# Altium schematic sheet MCU.SchDoc
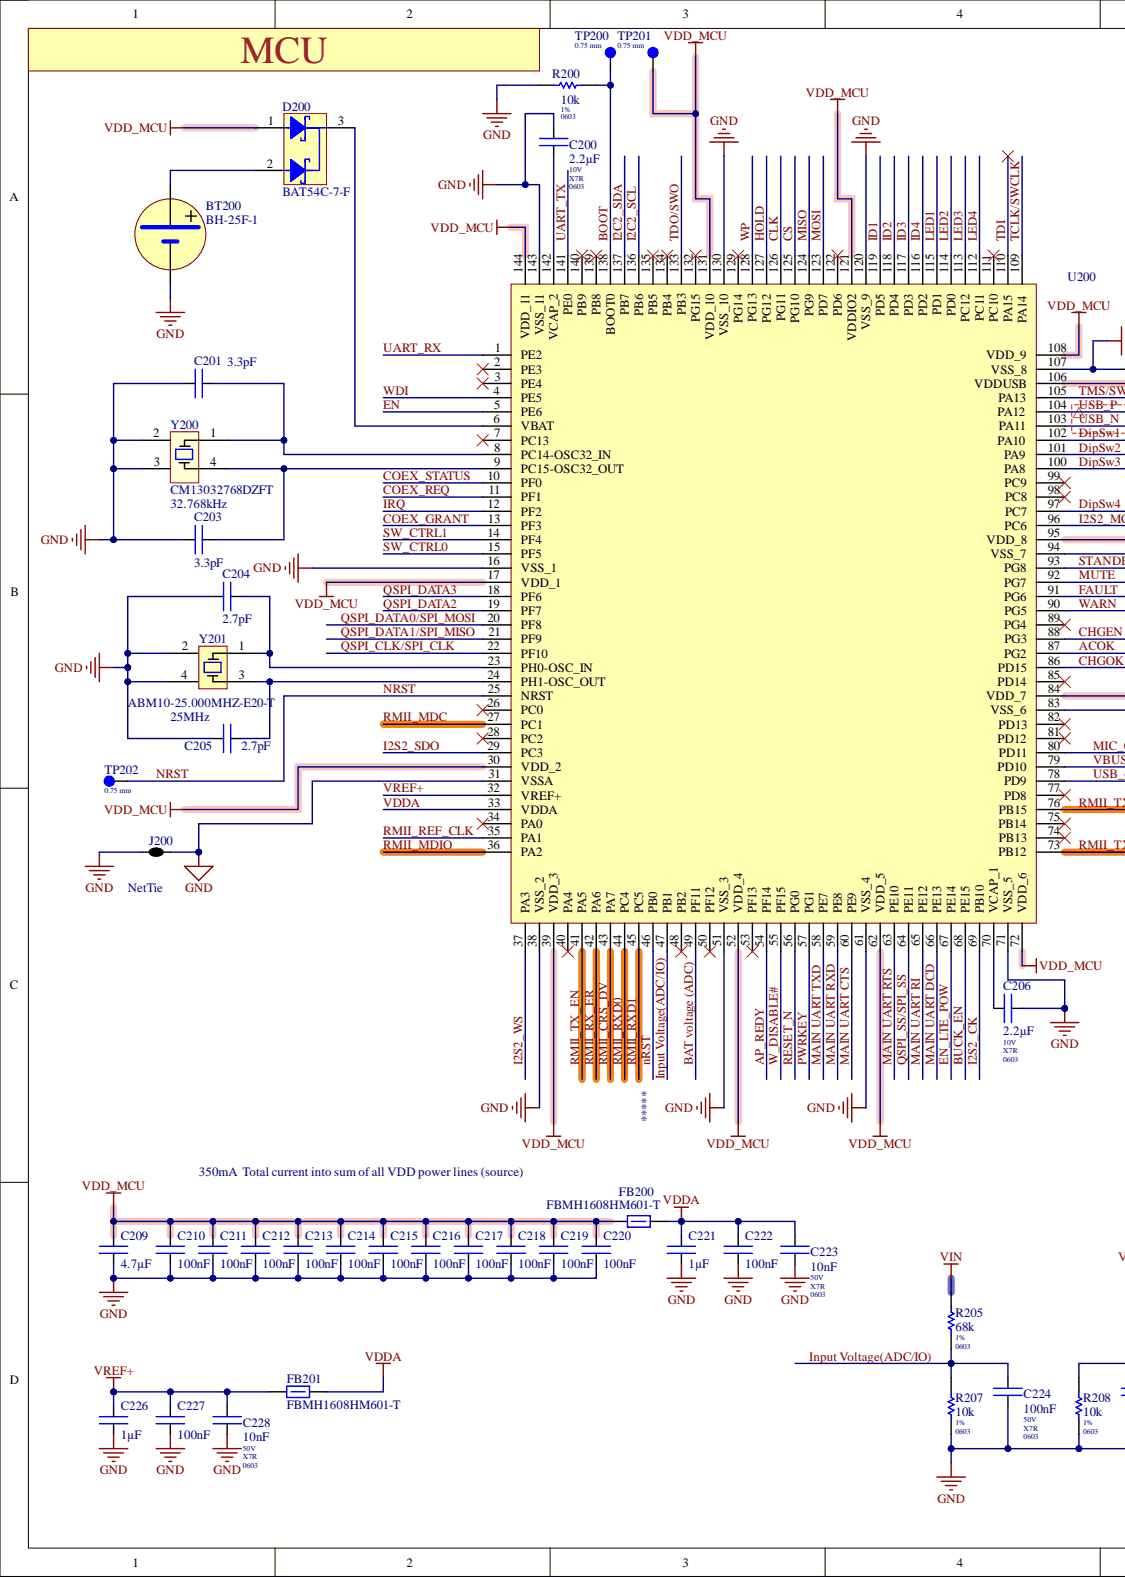
[diagram p0: MCU.SchDoc, left]
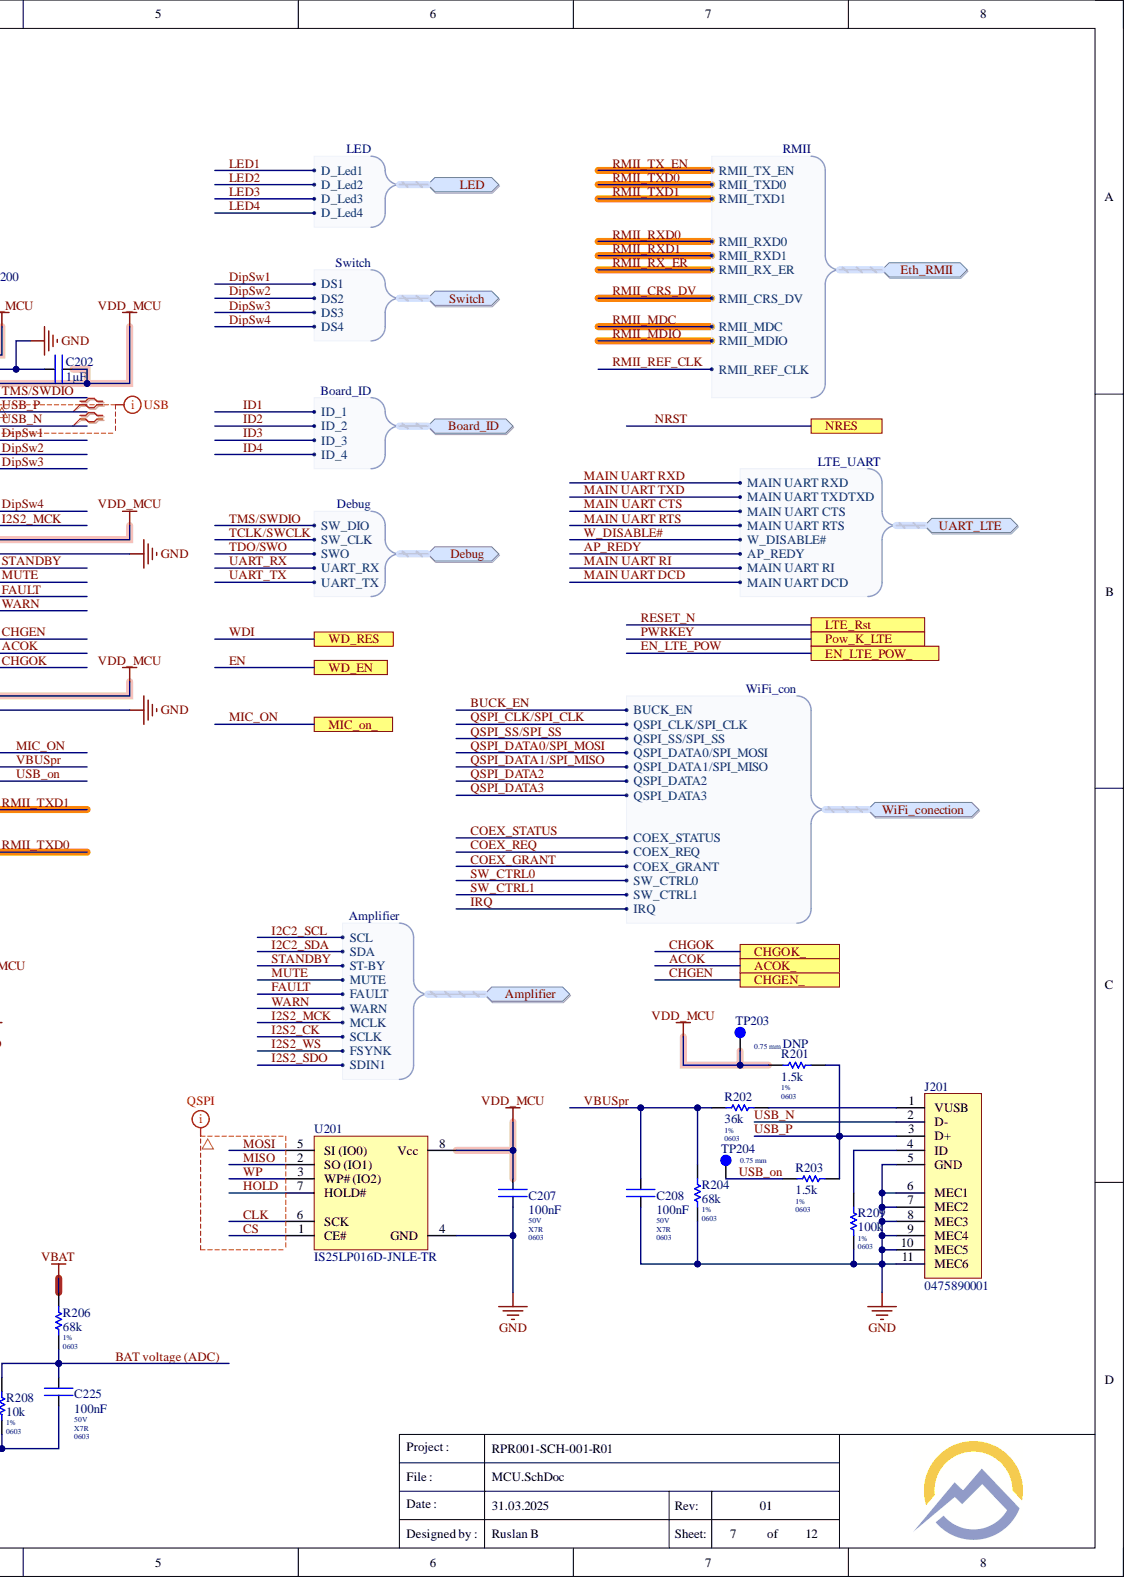
[diagram p1: MCU.SchDoc, right]

## Parts
BT200  BH-25F-1  [BH-25F-1]
C200  value 2.2µF  Case/Package: 0603  Dielectric: X7R  Voltage Rating: 10V  [B_Cap_0603_KEM]  (hidden comment: CL10B225KP8NNNC)
C201  value 3.3pF  [B_Cap_0402_KEM]  (hidden comment: GRM1555C1H3R3WA01D)
C202  value 1µF  [B_Cap_0402_KEM]  (hidden comment: EMK105BJ105KV-F)
C203  value 3.3pF  [B_Cap_0402_KEM]  (hidden comment: GRM1555C1H3R3WA01D)
C204  value 2.7pF  [B_Cap_0402_KEM]  (hidden comment: CC0402BRNPO9BN2R7)
C205  value 2.7pF  [B_Cap_0402_KEM]  (hidden comment: CC0402BRNPO9BN2R7)
C206  value 2.2µF  Dielectric: X7R  Voltage Rating: 10V  [B_Cap_0603_KEM]  (hidden comment: CL10B225KP8NNNC)
C207  value 100nF  Case/Package: 0603  Dielectric: X7R  Voltage Rating: 50V  [B_Cap_0603_KEM]  (hidden comment: GCM188R71H104KA57D)
C208  value 100nF  Case/Package: 0603  Dielectric: X7R  Voltage Rating: 50V  [B_Cap_0603_KEM]  (hidden comment: GCM188R71H104KA57D)
C209  value 4.7µF  [B_Cap_0402_KEM]  (hidden comment: CL05A475MO5NUNC)
C210  value 100nF  [B_Cap_0402_KEM]  (hidden comment: GRM155R71H104ME14D)
C211  value 100nF  [B_Cap_0402_KEM]  (hidden comment: GRM155R71H104ME14D)
C212  value 100nF  [B_Cap_0402_KEM]  (hidden comment: GRM155R71H104ME14D)
C213  value 100nF  [B_Cap_0402_KEM]  (hidden comment: GRM155R71H104ME14D)
C214  value 100nF  [B_Cap_0402_KEM]  (hidden comment: GRM155R71H104ME14D)
C215  value 100nF  [B_Cap_0402_KEM]  (hidden comment: GRM155R71H104ME14D)
C216  value 100nF  [B_Cap_0402_KEM]  (hidden comment: GRM155R71H104ME14D)
C217  value 100nF  [B_Cap_0402_KEM]  (hidden comment: GRM155R71H104ME14D)
C218  value 100nF  [B_Cap_0402_KEM]  (hidden comment: GRM155R71H104ME14D)
C219  value 100nF  [B_Cap_0402_KEM]  (hidden comment: GRM155R71H104ME14D)
C220  value 100nF  [B_Cap_0402_KEM]  (hidden comment: GRM155R71H104ME14D)
C221  value 1µF  [B_Cap_0402_KEM]  (hidden comment: EMK105BJ105KV-F)
C222  value 100nF  [B_Cap_0402_KEM]  (hidden comment: GRM155R71H104ME14D)
C223  value 10nF  Case/Package: 0603  Dielectric: X7R  Voltage Rating: 50V  [B_Cap_0603_KEM]  (hidden comment: CC0603MRX7R9BB103)
C224  value 100nF  Case/Package: 0603  Dielectric: X7R  Voltage Rating: 50V  [B_Cap_0603_KEM]  (hidden comment: GCM188R71H104KA57D)
C225  value 100nF  Case/Package: 0603  Dielectric: X7R  Voltage Rating: 50V  [B_Cap_0603_KEM]  (hidden comment: GCM188R71H104KA57D)
C226  value 1µF  [B_Cap_0402_KEM]  (hidden comment: EMK105BJ105KV-F)
C227  value 100nF  [B_Cap_0402_KEM]  (hidden comment: GRM155R71H104ME14D)
C228  value 10nF  Case/Package: 0603  Dielectric: X7R  Voltage Rating: 50V  [B_Cap_0603_KEM]  (hidden comment: CC0603MRX7R9BB103)
D200  BAT54C-7-F  [TO-236-3, SC-59, SOT-23-3]
FB200  FBMH1608HM601-T  [FBMH1608HM601-T-Footprint-1]
FB201  FBMH1608HM601-T  [FBMH1608HM601-T-Footprint-1]
J200  NetTie  [NetTie_2way_LP]
J201  0475890001  [PCBComponent]
R200  value 10k  [Res_0603_YAG]  (hidden comment: RC0603FR-0710KL)
R201  value 1.5k  Assembly: DNP  Tolerance: 1%  [Res_0603_YAG]  (hidden comment: RC0603FR-071K5L)
R202  value 36k  Case/Package: 0603  Tolerance: 1%  [Res_0603_YAG]  (hidden comment: RC0603FR-0736KL)
R203  value 1.5k  Case/Package: 0603  Tolerance: 1%  [Res_0603_YAG]  (hidden comment: RC0603FR-071K5L)
R204  value 68k  Case/Package: 0603  Tolerance: 1%  [Res_0603_YAG]  (hidden comment: RC0603FR-0768KL)
R205  value 68k  Case/Package: 0603  Tolerance: 1%  [Res_0603_YAG]  (hidden comment: RC0603FR-0768KL)
R206  value 68k  Case/Package: 0603  Tolerance: 1%  [Res_0603_YAG]  (hidden comment: RC0603FR-0768KL)
R207  value 10k  Case/Package: 0603  Tolerance: 1%  [Res_0603_YAG]  (hidden comment: RC0603FR-0710KL)
R208  value 10k  Case/Package: 0603  Tolerance: 1%  [Res_0603_YAG]  (hidden comment: RC0603FR-0710KL)
R209  value 100k  Case/Package: 0603  Tolerance: 1%  [Res_0603_YAG]  (hidden comment: RMCF0603FT100K)
TP200  0.75 mm  [0.75mm]  (no BOM)
TP201  0.75 mm  [0.75mm]  (no BOM)
TP202  0.75 mm  [0.75mm]  (no BOM)
TP203  0.75 mm  [0.75mm]  (no BOM)
TP204  0.75 mm  [0.75mm]  (no BOM)
U200  (no value)  [STM32H573ZIT6]
U201  IS25LP016D-JNLE-TR  [8-SOIC]
Y200  CM13032768DZFT  [CM130]
Y201  ABM10-25.000MHZ-E20-T  [4-SMD, No Lead]

## Ports
ACOK_, Amplifier, Amplifier.FAULT, Amplifier.FSYNK, Amplifier.MCLK, Amplifier.MUTE, Amplifier.SCL, Amplifier.SCLK, Amplifier.SDA, Amplifier.SDIN1, Amplifier.ST-BY, Amplifier.WARN, Board_ID, Board_ID.ID_1, Board_ID.ID_2, Board_ID.ID_3, Board_ID.ID_4, CHGEN_, CHGOK_, Debug, Debug.SW_CLK, Debug.SW_DIO, Debug.SWO, Debug.UART_RX, Debug.UART_TX, EN_LTE_POW_, Eth_RMII, Eth_RMII.RMII_CRS_DV, Eth_RMII.RMII_MDC, Eth_RMII.RMII_MDIO, Eth_RMII.RMII_REF_CLK, Eth_RMII.RMII_RX_ER, Eth_RMII.RMII_RXD0, Eth_RMII.RMII_RXD1, Eth_RMII.RMII_TX_EN, Eth_RMII.RMII_TXD0, Eth_RMII.RMII_TXD1, LED, LED.D_Led1, LED.D_Led2, LED.D_Led3, LED.D_Led4, LTE_Rst, MIC_on_, NRES, Pow_K_LTE, Switch, Switch.DS1, Switch.DS2, Switch.DS3, Switch.DS4, UART_LTE, UART_LTE.AP_REDY, UART_LTE.MAIN UART CTS, UART_LTE.MAIN UART DCD, UART_LTE.MAIN UART RI, UART_LTE.MAIN UART RTS, UART_LTE.MAIN UART RXD, UART_LTE.MAIN UART TXDTXD, UART_LTE.W_DISABLE#, WD_EN, WD_RES, WiFi_conection, WiFi_conection.BUCK_EN, WiFi_conection.COEX_GRANT, WiFi_conection.COEX_REQ, WiFi_conection.COEX_STATUS, WiFi_conection.IRQ, WiFi_conection.QSPI_CLK/SPI_CLK, WiFi_conection.QSPI_DATA0/SPI_MOSI, WiFi_conection.QSPI_DATA1/SPI_MISO, WiFi_conection.QSPI_DATA2, WiFi_conection.QSPI_DATA3, WiFi_conection.QSPI_SS/SPI_SS, WiFi_conection.SW_CTRL0, WiFi_conection.SW_CTRL1

## Nets
GND: BT200.1 C200.1 C201.1 C202.2 C203.2 C204.2 C205.2 C206.1 C207.1 C208.1 C209.1 C210.1 C211.1 C212.1 C213.1 C214.1 C215.1 C216.1 C217.1 C218.1 C219.1 C220.1 C221.1 C222.1 C223.1 C224.1 C225.1 C226.1 C227.1 C228.1 J200.1 J200.2 J201.5(GND) J201.6(MEC1) J201.7(MEC2) J201.8(MEC3) J201.9(MEC4) J201.10(MEC5) J201.11(MEC6) R200.1 R204.1 R207.1 R208.1 R209.2 U200.16(VSS_1) U200.31(VSSA) U200.38(VSS_2) U200.51(VSS_3) U200.61(VSS_4) U200.71(VSS_5) U200.83(VSS_6) U200.94(VSS_7) U200.107(VSS_8) U200.120(VSS_9) U200.130(VSS_10) U200.143(VSS_11) U201.4(GND) Y200.2 Y200.3 Y201.2 Y201.4
VBAT: R206.2
VDD_MCU: C202.1 C207.2 C209.2 C210.2 C211.2 C212.2 C213.2 C214.2 C215.2 C216.2 C217.2 C218.2 C219.2 C220.2 D200.1 FB200.2 R201.1 TP201.1 TP203.1 U200.17(VDD_1) U200.30(VDD_2) U200.39(VDD_3) U200.52(VDD_4) U200.62(VDD_5) U200.72(VDD_6) U200.84(VDD_7) U200.95(VDD_8) U200.106(VDDUSB) U200.108(VDD_9) U200.121(VDDIO2) U200.131(VDD_10) U200.144(VDD_11) U201.8(Vcc)
VIN: R205.2
ACOK: U200.87(PG2) ; port ACOK_
AP_REDY: U200.54(PF14) ; port UART_LTE
BAT voltage (ADC): C225.2 R206.1 R208.2 U200.49(PF11)
BOOT: R200.2 TP200.1 U200.138(BOOT0)
BUCK_EN: U200.68(PE15) ; port WiFi_conection
CHGEN: U200.88(PG3) ; port CHGEN_
CHGOK: U200.86(PD15) ; port CHGOK_
CLK: U200.126(PG11) U201.6(SCK)
COEX_GRANT: U200.13(PF3) ; port WiFi_conection
COEX_REQ: U200.11(PF1) ; port WiFi_conection
COEX_STATUS: U200.10(PF0) ; port WiFi_conection
CS: U200.125(PG10) U201.1(CE#)
DipSw1: U200.102(PA10) ; port Switch
DipSw2: U200.101(PA9) ; port Switch
DipSw3: U200.100(PA8) ; port Switch
DipSw4: U200.97(PC7) ; port Switch
EN: U200.5(PE6) ; port WD_EN
EN_LTE_POW: U200.67(PE14) ; port EN_LTE_POW_
FAULT: U200.91(PG6) ; port Amplifier
HOLD: U200.127(PG12) U201.7(HOLD#)
I2C2_SCL: U200.136(PB6) ; port Amplifier
I2C2_SDA: U200.137(PB7) ; port Amplifier
I2S2_CK: U200.69(PB10) ; port Amplifier
I2S2_MCK: U200.96(PC6) ; port Amplifier
I2S2_SDO: U200.29(PC3) ; port Amplifier
I2S2_WS: U200.37(PA3) ; port Amplifier
ID1: U200.119(PD5) ; port Board_ID
ID2: U200.118(PD4) ; port Board_ID
ID3: U200.117(PD3) ; port Board_ID
ID4: U200.116(PD2) ; port Board_ID
Input Voltage(ADC/IO): C224.2 R205.1 R207.2 U200.47(PB1)
IRQ: U200.12(PF2) ; port WiFi_conection
LED1: U200.115(PD1) ; port LED
LED2: U200.114(PD0) ; port LED
LED3: U200.113(PC12) ; port LED
LED4: U200.112(PC11) ; port LED
MAIN UART CTS: U200.60(PE9) ; port UART_LTE
MAIN UART DCD: U200.66(PE13) ; port UART_LTE
MAIN UART RI: U200.65(PE12) ; port UART_LTE
MAIN UART RTS: U200.63(PE10) ; port UART_LTE
MAIN UART RXD: U200.59(PE8) ; port UART_LTE
MAIN UART TXD: U200.58(PE7) ; port UART_LTE
MIC_ON: U200.80(PD11) ; port MIC_on_
MISO: U200.124(PG9) U201.2(SO_(IO1))
MOSI: U200.123(PD7) U201.5(SI_(IO0))
MUTE: U200.92(PG7) ; port Amplifier
nRST: TP202.1 U200.25(NRST) U200.46(PB0) ; port NRES
PWRKEY: U200.57(PG1) ; port Pow_K_LTE
QSPI_CLK/SPI_CLK: U200.22(PF10) ; port WiFi_conection
QSPI_DATA0/SPI_MOSI: U200.20(PF8) ; port WiFi_conection
QSPI_DATA1/SPI_MISO: U200.21(PF9) ; port WiFi_conection
QSPI_DATA2: U200.19(PF7) ; port WiFi_conection
QSPI_DATA3: U200.18(PF6) ; port WiFi_conection
QSPI_SS/SPI_SS: U200.64(PE11) ; port WiFi_conection
RESET_N: U200.56(PG0) ; port LTE_Rst
RMII_CRS_DV: U200.43(PA7) ; port Eth_RMII
RMII_MDC: U200.27(PC1) ; port Eth_RMII
RMII_MDIO: U200.36(PA2) ; port Eth_RMII
RMII_REF_CLK: U200.35(PA1) ; port Eth_RMII
RMII_RX_ER: U200.42(PA6) ; port Eth_RMII
RMII_RXD0: U200.44(PC4) ; port Eth_RMII
RMII_RXD1: U200.45(PC5) ; port Eth_RMII
RMII_TX_EN: U200.41(PA5) ; port Eth_RMII
RMII_TXD0: U200.73(PB12) ; port Eth_RMII
RMII_TXD1: U200.76(PB15) ; port Eth_RMII
STANDBY: U200.93(PG8) ; port Amplifier
SW_CTRL0: U200.15(PF5) ; port WiFi_conection
SW_CTRL1: U200.14(PF4) ; port WiFi_conection
TCLK/SWCLK: U200.109(PA14) ; port Debug
TDI: U200.110(PA15)
TDO/SWO: U200.133(PB3) ; port Debug
TMS/SWDIO: U200.105(PA13) ; port Debug
UART_RX: U200.1(PE2) ; port Debug
UART_TX: U200.141(PE0) ; port Debug
USB_N: J201.2(D-) U200.103(PA11)
USB_on: R203.1 TP204.1 U200.78(PD9)
USB_P: J201.3(D+) R201.2 R203.2 U200.104(PA12)
VBUSpr: C208.2 R202.1 R204.2 U200.79(PD10)
VDDA: C221.2 C222.2 C223.2 FB200.1 FB201.1 U200.33(VDDA)
VREF+: C226.2 C227.2 C228.2 FB201.2 U200.32(VREF+)
W_DISABLE#: U200.55(PF15) ; port UART_LTE
WARN: U200.90(PG5) ; port Amplifier
WDI: U200.4(PE5) ; port WD_RES
WP: U200.128(PG13) U201.3(WP#_(IO2))
NetBT200_2: BT200.2 D200.2
NetC200_2: C200.2 U200.142(VCAP_2)
NetC201_2: C201.2 U200.8(PC14-OSC32_IN) Y200.1
NetC203_1: C203.1 U200.9(PC15-OSC32_OUT) Y200.4
NetC204_1: C204.1 U200.23(PH0-OSC_IN) Y201.1
NetC205_1: C205.1 U200.24(PH1-OSC_OUT) Y201.3
NetC206_2: C206.2 U200.70(VCAP_1)
NetD200_3: D200.3 U200.6(VBAT)
NetJ201_1: J201.1(VUSB) R202.2
NetJ201_4: J201.4(ID) R209.1

Not connected: U200 2(PE3) 3(PE4) 7(PC13) 26(PC0) 28(PC2) 34(PA0) 40(PA4) 48(PB2) 50(PF12) 53(PF13) 74(PB13) 75(PB14) 77(PD8) 81(PD12) 82(PD13) 85(PD14) 89(PG4) 98(PC8) 99(PC9) 111(PC10) 122(PD6) 129(PG14) 132(PG15) 134(PB4) 135(PB5) 139(PB8) 140(PB9)

## Library data of the parts
BT200 = CMP-POW-BAT-00020-3: BATTERY HOLDER CR 2032; Manufacturer 1: Adam Equipment; Number of Cells: 1; Component Kind: Standard; ComponentLink1Description: Datasheet; ComponentLink1URL: https://www.adam-tech.com/downloader.php?p=BH-25F-1.pdf; Library Name: <Company> Server; Manufacturer Part Number 1: BH-25F-1
C200 C206 = CMP-CAP-CER-00144-13: CAP CER 2.2UF 10V X7R 0603; Manufacturer 1: Samsung; Tolerance: ±10%; Component Kind: Standard; ComponentLink1Description: Datasheet; ComponentLink1URL: http://www.samsungsem.com/kr/support/product-search/mlcc/CL10B225KP8NNNC.jsp; Library Name: <Company> Server; Manufacturer Part Number 1: CL10B225KP8NNNC
  C206: Case/Package: 0603
C201 C203 = CMP-CAP-CER-00179-16: CAP CER 3.3PF 50V C0G/NP0 0402; Manufacturer 1: Murata; Tolerance: ±0.05pF; Component Kind: Standard; ComponentLink1Description: Datasheet; ComponentLink1URL: https://www.murata.com/~/media/webrenewal/support/library/catalog/products/capacitor/mlcc/c02e.ashx?la=en-us; Library Name: <Company> Server; Manufacturer Part Number 1: GRM1555C1H3R3WA01D
C202 C221 C226 = CMP-CAP-CER-00130-16: CAP CER 1UF 16V X5R 0402; Manufacturer 1: Taiyo Yuden; Tolerance: 10%; Component Kind: Standard; ComponentLink1Description: Datasheet; ComponentLink1URL: https://ds.yuden.co.jp/TYCOMPAS/ut/detail?pn=EMK105BJ105KV-F%20%20&u=M; Library Name: <Company> Server; Manufacturer Part Number 1: EMK105BJ105KV-F
C204 C205 = CMP-CAP-CER-00295-1: Multilayer Ceramic Capacitor, 2.7 pF, 50 V, ï¿½ 0.25pF, C0G (NP0), 0402 [1005 Metric]; Manufacturer 1: YAGEO; Component Kind: Standard; Library Name: <Company> Server; Manufacturer Part Number 1: CC0402BRNPO9BN2R7
C207 C208 C224 C225 = CMP-CAP-CER-00027-13: CAP CER 0.1UF 50V X7R 0603; Manufacturer 1: Murata; Tolerance: ±10%; Component Kind: Standard; ComponentLink1Description: Datasheet; ComponentLink1URL: https://search.murata.co.jp/Ceramy/image/img/A01X/G101/ENG/GCM188R71H104KA57-01.pdf; Library Name: <Company> Server; Manufacturer Part Number 1: GCM188R71H104KA57D
C209 = CMP-CAP-CER-00202-15: CAP CER 4.7UF 16V X5R 0402; Manufacturer 1: Samsung; Tolerance: ±20%; Component Kind: Standard; ComponentLink1Description: Datasheet; ComponentLink1URL: http://www.samsungsem.com/kr/support/product-search/mlcc/__icsFiles/afieldfile/2019/05/29/Data%20sheet_CL05A475MO5NUNC.pdf; Library Name: <Company> Server; Manufacturer Part Number 1: CL05A475MO5NUNC
C210-C220 C222 C227 = CMP-CAP-CER-00025-17: CAP CER 0.1UF 50V X7R 0402; Manufacturer 1: Murata; Tolerance: ±20%; Component Kind: Standard; ComponentLink1Description: Datasheet; ComponentLink1URL: https://search.murata.co.jp/Ceramy/image/img/A01X/G101/ENG/GRM155R71H104ME14-01.pdf; Library Name: <Company> Server; Manufacturer Part Number 1: GRM155R71H104ME14D
C223 C228 = CMP-CAP-CER-00261-3: 0603 10 nF 50 V ±20% Tolerance X7R SMT Multilayer Ceramic Capacitor; Manufacturer 1: Yageo; Component Kind: Standard; Library Name: <Company> Server; Manufacturer Part Number 1: CC0603MRX7R9BB103; Tolerance: 20%
D200 = CMP-DIO-ARR-00002-8: DIODE ARRAY SCHOTTKY 30V SOT23-3; Manufacturer 1: Diodes; Average Rectified Current: 200mA; Element Configuration: 1 Pair Common Cathode; Forward Current: 100mA; Forward Voltage: 800mV; Max Reverse Current: 2µA; Power Dissipation: 200mW; Case/Package: TO-236-3, SC-59, SOT-23-3; Component Kind: Standard; ComponentLink1Description: Datasheet; ComponentLink1URL: https://www.diodes.com/assets/Datasheets/ds11005.pdf; Library Name: <Company> Server; Manufacturer Part Number 1: BAT54C-7-F; Max Reverse Voltage (DC): 30V
FB200 FB201 = CMP-FIL-FB-00013-5: FERRITE BEAD 600 OHM 0603 1LN; Manufacturer 1: Taiyo Yuden; Current Rating: 700mA; Impedance: 600; Tolerance: 25%; Case/Package: 0603; Component Kind: Standard; ComponentLink1Description: Datasheet; ComponentLink1URL: https://ds.yuden.co.jp/TYCOMPAS/ut/detail?pn=FBMH1608HM601-T  &u=M; Library Name: <Company> Server; Manufacturer Part Number 1: FBMH1608HM601-T
J200 = CMP-MF-NT-00002-1: 2 way net tie junction; Component Kind: Net Tie; Library Name: <Company> Server
J201 = CMP-CON-INF-00024-3: CONN RCPT MICRO USB AB 5P SMD RA; Manufacturer 1: Molex; Manufacturer Part Number 1: 47589-0001; Connector Type: USB - micro AB; Current Rating: 1A; Gender: Receptacle; Max Voltage Rating (AC): 30V; Number of Contacts: 5; Number of Ports: 1; Component Kind: Standard; ComponentLink1Description: Datasheet; ComponentLink1URL: https://www.molex.com/pdm_docs/sd/475890001_sd.pdf; Library Name: <Company> Server; Mount: Surface Mount, Right Angle; Through Hole
R200 R207 R208 = CMP-RES-SMD-00183-9: RES SMD 10K OHM 1% 1/10W 0603; Manufacturer 1: Yageo; Temperature Coefficient: ±100ppm/℃; Component Kind: Standard; ComponentLink1Description: Datasheet; ComponentLink1URL: https://www.yageo.com/upload/media/product/productsearch/datasheet/rchip/PYu-RC_Group_51_RoHS_L_10.pdf; Library Name: <Company> Server; Manufacturer Part Number 1: RC0603FR-0710KL
  R200: Case/Package: 0603; Tolerance: 1%
R201 R203 = CMP-RES-SMD-00543-2: SMD Chip Resistor, 1.5 kOhm, ± 1%, 100 mW, 0603 [1608 Metric], Thick Film, General Purpose; Manufacturer 1: Yageo; Power Rating: 100mW; Temperature Coefficient: 100ppm/°C; Voltage Rating: 50V; Component Kind: Standard; Library Name: <Company> Server; Manufacturer Part Number 1: RC0603FR-071K5L
  R201: Case/Package: 0603
R202 = CMP-RES-SMD-00313-9: RES SMD 36K OHM 1% 1/10W 0603; Manufacturer 1: Yageo; Temperature Coefficient: ±100ppm/℃; Component Kind: Standard; ComponentLink1Description: Datasheet; ComponentLink1URL: https://www.yageo.com/upload/media/product/productsearch/datasheet/rchip/PYu-RC_Group_51_RoHS_L_11.pdf; Library Name: <Company> Server; Manufacturer Part Number 1: RC0603FR-0736KL
R204-R206 = CMP-RES-SMD-00114-12: SMD Chip Resistor, 68 kOhm, ï¿½ 1%, 100 mW, 0603 [1608 Metric], Thick Film, General Purpose; Manufacturer 1: Yageo; Power Rating: 100mW; Temperature Coefficient: 100ppm/°C; Voltage Rating: 50V; Component Kind: Standard; Library Name: <Company> Server; Manufacturer Part Number 1: RC0603FR-0768KL
R209 = CMP-RES-SMD-00047-11: RES 100K OHM 1% 1/10W 0603; Manufacturer 1: Stackpole Electronics; Temperature Coefficient: ±100ppm/℃; Component Kind: Standard; ComponentLink1Description: Datasheet; ComponentLink1URL: https://www.seielect.com/catalog/sei-rmcf_rmcp.pdf; Library Name: <Company> Server; Manufacturer Part Number 1: RMCF0603FT100K
TP200-TP204 = CMP-MF-TP-00003-4: Test point 0.75 mm; Library Name: <Company> Server; Size: 0.75 mm
U200 = CMP-IC-MCU-00016-2: Mcu, 32 Bit, 250MHZ, LQFP-144 Rohs Compliant: Yes; Manufacturer 1: STMicroelectronics; Core Architecture: ARM® Cortex®-M33; Data Bus Width: 32-Bit; Interface: CANbus, Ethernet, I2C, IrDA, LINbus, MDIO, MMC/SD/SDIO, QSPI, SAI, SPDIF, SPI, UART/USART, USB OTG; Max Supply Voltage: 3.6V; Memory Size: 2MB (2M x 8); Memory Type: FLASH; Min Supply Voltage: 1.71V; Number of ADC Channels: A/D 20x12b SAR; D/A 2x12b; Number of I/Os: 112; RAM Size: 640K x 8; Watchdog Timer: WDT; Case/Package: 144-LQFP (20x20); Component Kind: Standard; Frequency: 250MHz; Library Name: <Company> Server; Manufacturer Part Number 1: STM32H573ZIT6
U201 = CMP-IC-MEM-00001-3: NOR Flash Serial (SPI, Dual SPI, Quad SPI) 2.5V/3V/3.3V 16M-bit 2M x 8 8ns 8-Pin SOIC N T/R; Manufacturer 1: ISSI; Clock Frequency: 133MHz; Memory Size: 16Mb; Memory Type: Non-Volatile,FLASH; Case/Package: 8-SOIC; Component Kind: Standard; Frequency: 133MHz; Library Name: <Company> Server; Manufacturer Part Number 1: IS25LP016D-JNLE-TR
Y200 = CMP-CRaO-CR-00038-1: Crystal 0.032768MHz ±20ppm (Tol) 12.5pF Flexural 65000Ohm 4-Pin SMD T/R; Manufacturer 1: Citizen Finedevice Co Ltd; Manufacturer Part Number 1: CM13032768DZCT; ESR (Equivalent Series Resistance): 65k; Frequency: 32.768kHz; Value: 1.25E-11F; Case/Package: SMD/SMT; Component Kind: Standard; Frequency Tolerance: 20ppm; Library Name: <Company> Server; Load Capacitance: 12.5pF; Mount: SMD; RoHS Compliant: Yes
Y201 = CMP-CRaO-CR-00007-5: CRYSTAL 25.0000MHZ 10PF SMD; Manufacturer 1: Abracon; ESR (Equivalent Series Resistance): 100; Frequency: 25MHz; Frequency Stability: ±20ppm; Component Kind: Standard; ComponentLink1Description: Datasheet; ComponentLink1URL: https://abracon.com/Resonators/ABM10.pdf; Library Name: <Company> Server; Load Capacitance: 10pF; Manufacturer Part Number 1: ABM10-25.000MHZ-E20-T
